# Altium schematic sheet GPIO Schematic.SchDoc("GPIO Schematic")
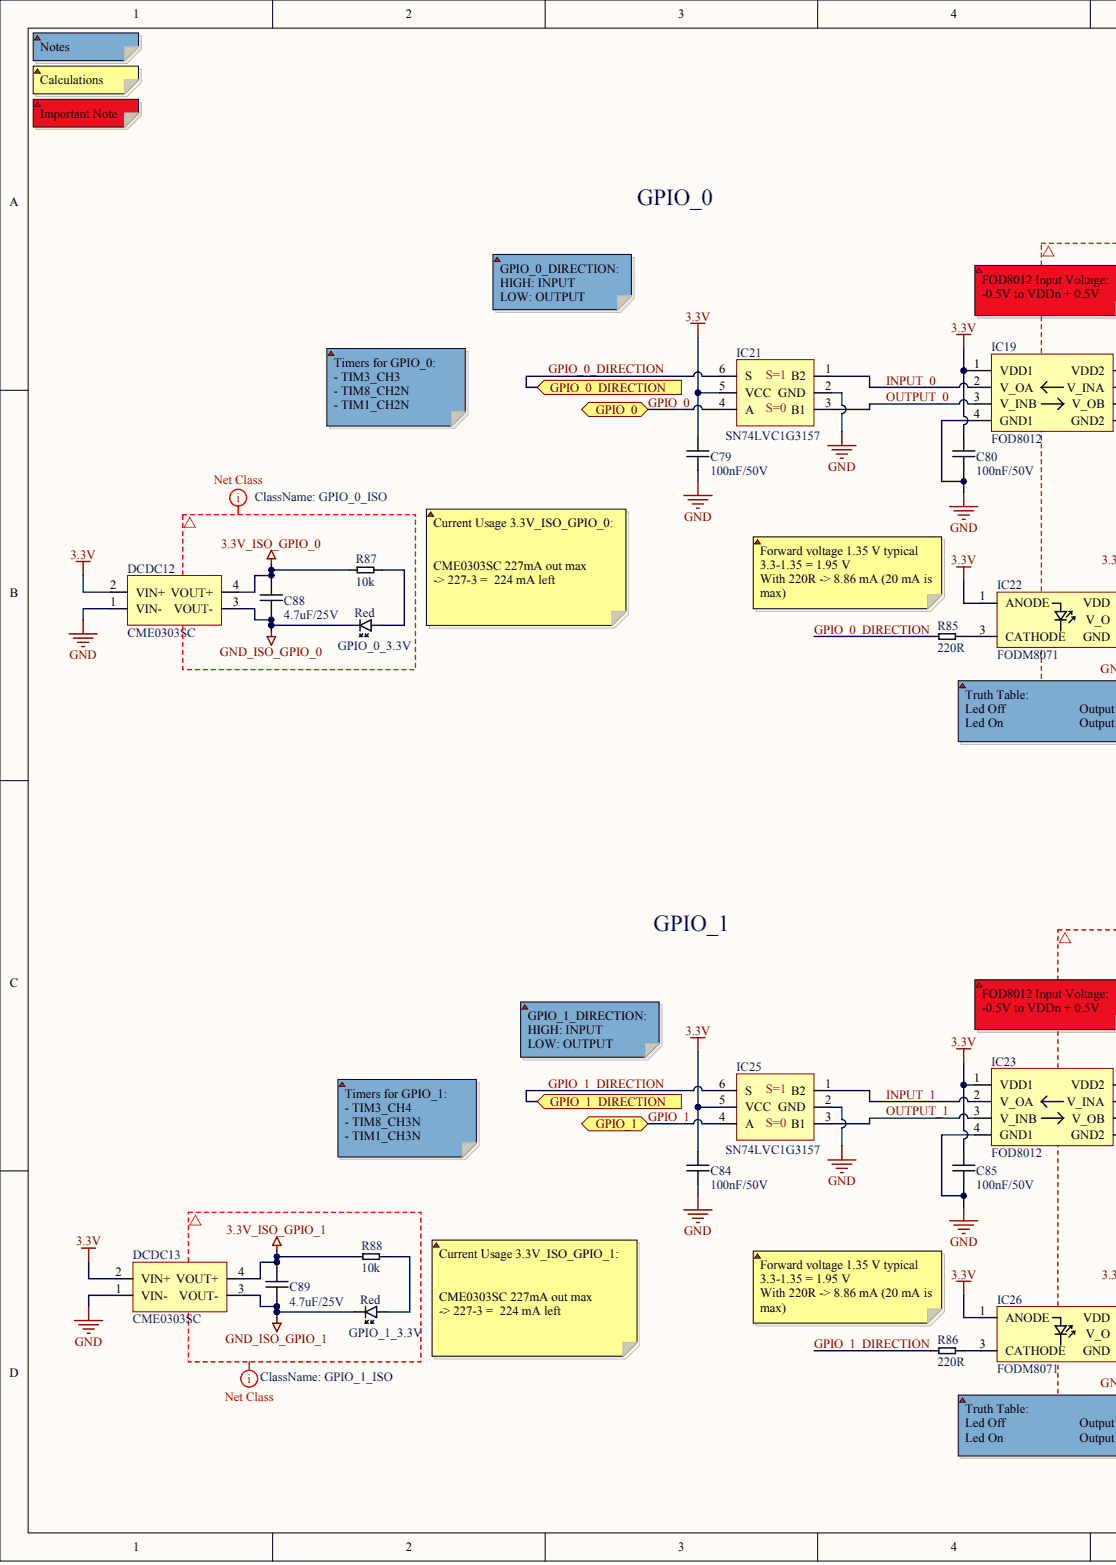
[diagram p0: GPIO Schematic.SchDoc, left]
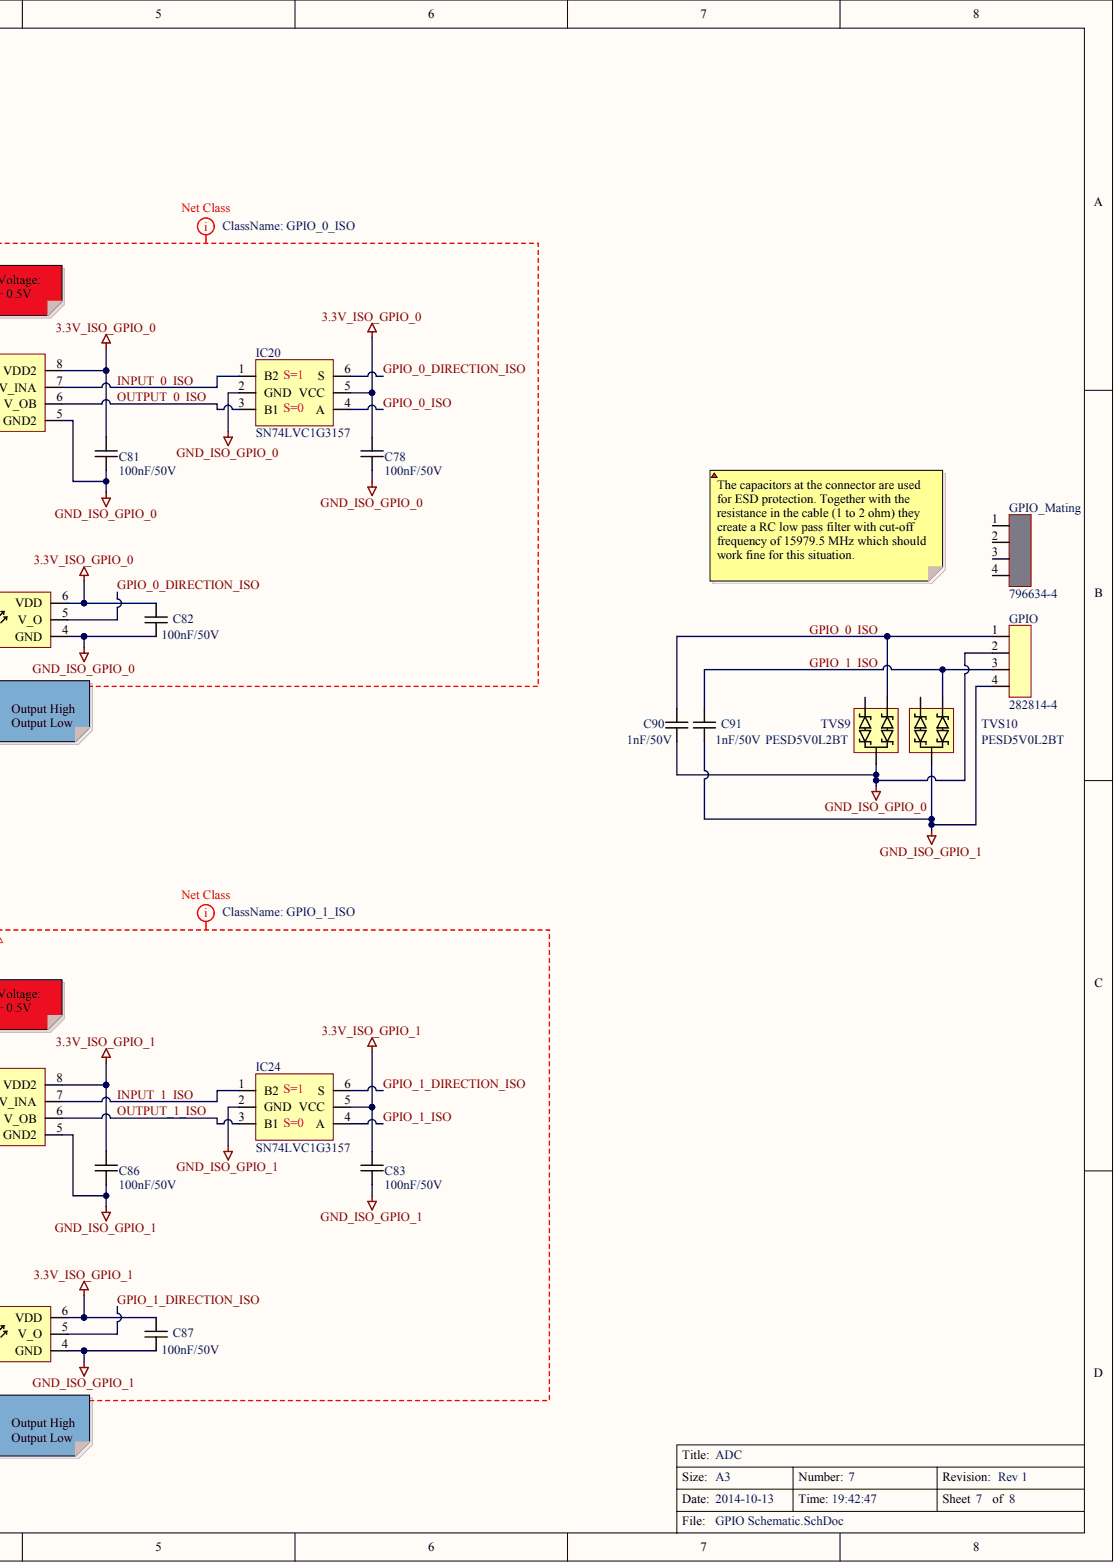
[diagram p1: GPIO Schematic.SchDoc, right]

## Parts
C78  value 100nF/50V  [0603]  (hidden comment: Cap_0603_100nF/50V)
C79  value 100nF/50V  [0603]  (hidden comment: Cap_0603_100nF/50V)
C80  value 100nF/50V  [0603]  (hidden comment: Cap_0603_100nF/50V)
C81  value 100nF/50V  [0603]  (hidden comment: Cap_0603_100nF/50V)
C82  value 100nF/50V  [0603]  (hidden comment: Cap_0603_100nF/50V)
C83  value 100nF/50V  [0603]  (hidden comment: Cap_0603_100nF/50V)
C84  value 100nF/50V  [0603]  (hidden comment: Cap_0603_100nF/50V)
C85  value 100nF/50V  [0603]  (hidden comment: Cap_0603_100nF/50V)
C86  value 100nF/50V  [0603]  (hidden comment: Cap_0603_100nF/50V)
C87  value 100nF/50V  [0603]  (hidden comment: Cap_0603_100nF/50V)
C88  value 4.7uF/25V  [0805]  (hidden comment: Cap_0805_4.7uF/25V)
C89  value 4.7uF/25V  [0805]  (hidden comment: Cap_0805_4.7uF/25V)
C90  value 1nF/50V  [0603]  (hidden comment: Cap_0603_1nF/50V)
C91  value 1nF/50V  [0603]  (hidden comment: Cap_0603_1nF/50V)
DCDC12  CME0303SC  [4-SIP]
DCDC13  CME0303SC  [4-SIP]
GPIO  value 282814-4  Part No.: 282814-4  (hidden comment: Terminal Block 1x4 5.08mm)
GPIO_0_3.3V  value Red  (hidden comment: LED_0603_Red)
GPIO_1_3.3V  value Red  (hidden comment: LED_0603_Red)
GPIO_Mating  value 796634-4  Part No.: 796634-4  (hidden comment: Terminal Block 1x4 5.08mm Mating Connector)
IC19  FOD8012
IC20  SN74LVC1G3157
IC21  SN74LVC1G3157
IC22  FODM8071
IC23  FOD8012
IC24  SN74LVC1G3157
IC25  SN74LVC1G3157
IC26  FODM8071
R85  value 220R  [0603]  (hidden comment: Res_0603_220R)
R86  value 220R  [0603]  (hidden comment: Res_0603_220R)
R87  value 10k  [0603]  (hidden comment: Res_0603_10k)
R88  value 10k  [0603]  (hidden comment: Res_0603_10k)
TVS9  PESD5V0L2BT
TVS10  PESD5V0L2BT

## Ports
GPIO_0, GPIO_0_DIRECTION, GPIO_1, GPIO_1_DIRECTION

## Nets
3.3V: C79.2 C80.2 C84.2 C85.2 DCDC12.2(VIN+) DCDC13.2(VIN+) IC19.1(VDD1) IC21.5(VCC) IC22.1(ANODE) IC23.1(VDD1) IC25.5(VCC) IC26.1(ANODE)
3.3V_ISO_GPIO_0: C78.2 C81.2 C82.2 C88.2 DCDC12.4(VOUT+) IC19.8(VDD2) IC20.5(VCC) IC22.6(VDD) R87.2
3.3V_ISO_GPIO_1: C83.2 C86.2 C87.2 C89.2 DCDC13.4(VOUT+) IC23.8(VDD2) IC24.5(VCC) IC26.6(VDD) R88.2
GND: C79.1 C80.1 C84.1 C85.1 DCDC12.1(VIN-) DCDC13.1(VIN-) IC19.4(GND1) IC21.2(GND) IC23.4(GND1) IC25.2(GND)
GND_ISO_GPIO_0: C78.1 C81.1 C82.1 C88.1 C90.1 DCDC12.3(VOUT-) GPIO.2 GPIO_0_3.3V.CATHODE IC19.5(GND2) IC20.2(GND) IC22.4(GND) TVS9.3
GND_ISO_GPIO_1: C83.1 C86.1 C87.1 C89.1 C91.1 DCDC13.3(VOUT-) GPIO.4 GPIO_1_3.3V.CATHODE IC23.5(GND2) IC24.2(GND) IC26.4(GND) TVS10.3
GPIO_0: IC21.4(A) ; port GPIO_0
GPIO_0_DIRECTION: IC21.6(S) R85.1 ; port GPIO_0_DIRECTION
GPIO_0_DIRECTION_ISO: IC20.6(S) IC22.5(V_O)
GPIO_0_ISO: C90.2 GPIO.1 IC20.4(A) TVS9.1
GPIO_1: IC25.4(A) ; port GPIO_1
GPIO_1_DIRECTION: IC25.6(S) R86.1 ; port GPIO_1_DIRECTION
GPIO_1_DIRECTION_ISO: IC24.6(S) IC26.5(V_O)
GPIO_1_ISO: C91.2 GPIO.3 IC24.4(A) TVS10.1
INPUT_0: IC19.2(V_OA) IC21.1(B2)
INPUT_0_ISO: IC19.7(V_INA) IC20.1(B2_S=1)
INPUT_1: IC23.2(V_OA) IC25.1(B2)
INPUT_1_ISO: IC23.7(V_INA) IC24.1(B2_S=1)
OUTPUT_0: IC19.3(V_INB) IC21.3(B1)
OUTPUT_0_ISO: IC19.6(V_OB) IC20.3(B1_S=0)
OUTPUT_1: IC23.3(V_INB) IC25.3(B1)
OUTPUT_1_ISO: IC23.6(V_OB) IC24.3(B1_S=0)
NetGPIO_0_3.3V_ANODE: GPIO_0_3.3V.ANODE R87.1
NetGPIO_1_3.3V_ANODE: GPIO_1_3.3V.ANODE R88.1
NetIC22_3: IC22.3(CATHODE) R85.2
NetIC26_3: IC26.3(CATHODE) R86.2
N00142:
N00143:
N00144:
N00145:

Not connected: GPIO_Mating 1 2 3 4; TVS9 2; TVS10 2

## Library data of the parts
DCDC12 DCDC13 = (no symbol name): Part No.: CME0303S3C; Manufacturer: Murata; DigiKey: 811-2889-5-ND; Mouser: 580-CME0303S3C; Datasheet: http://www.murata-ps.com/data/power/ncl/kdc_cme.pdf; Price SEK (No VAT): 28
GPIO = (no symbol name): Manufacturer: TE Connectivity; Mating Connector: 796634-4; Mouser: 571-282814-4; RS: *; DigiKey: A98067-ND; Elfa: *; Price SEK (No VAT): 8
GPIO_Mating = (no symbol name): Manufacturer: TE Connectivity; Mouser: 571-7966344; RS: *; DigiKey: A98225-ND; Elfa: *; Price SEK (No VAT): 18
IC19 IC23 = (no symbol name): Manufacturer: Fairchild Semiconductor; Note: Should be able to replace ADUM1201 as pin-compatible; DigiKey: FOD8012-ND; Part No.: FOD8012; Price SEK (No VAT): 31; Datasheet: http://www.fairchildsemi.com/ds/FO/FOD8012.pdf; Mouser: 512-FOD8012; Value: FOD8012
IC20 IC21 IC24 IC25 = (no symbol name): Part No.: SN74LVC1G3157DBVR; Manufacturer: TI; DigiKey: 296-14908-1-ND; Price SEK (No VAT): 3; Datasheet: http://www.ti.com/lit/ds/symlink/sn74lvc1g3157.pdf; Mouser: 595-SN74LVC1G3157DBV; Value: SN74LVC1G3157
IC22 IC26 = (no symbol name): Manufacturer: Fairchild Semiconductor; DigiKey: FODM8071-ND; Part No.: FODM8071; Price SEK (No VAT): 14; Datasheet: http://www.fairchildsemi.com/ds/FO/FODM8071.pdf; Mouser: 512-FODM8071; Value: FODM8071
TVS9 TVS10 = (no symbol name): Manufacturer: NXP; Part No.: PESD5V0L2BT,215; Mouser: 771-PESD5V0L2BT-T/R; DigiKey: 568-4050-1-ND; Price SEK (No VAT): 4; Value: PESD5V0L2BT
C78-C91 GPIO_0_3.3V GPIO_1_3.3V R85-R88 = (no symbol name)
  R85-R88: Price (No VAT): 0,1
